# Altium schematic sheet main.SchDoc
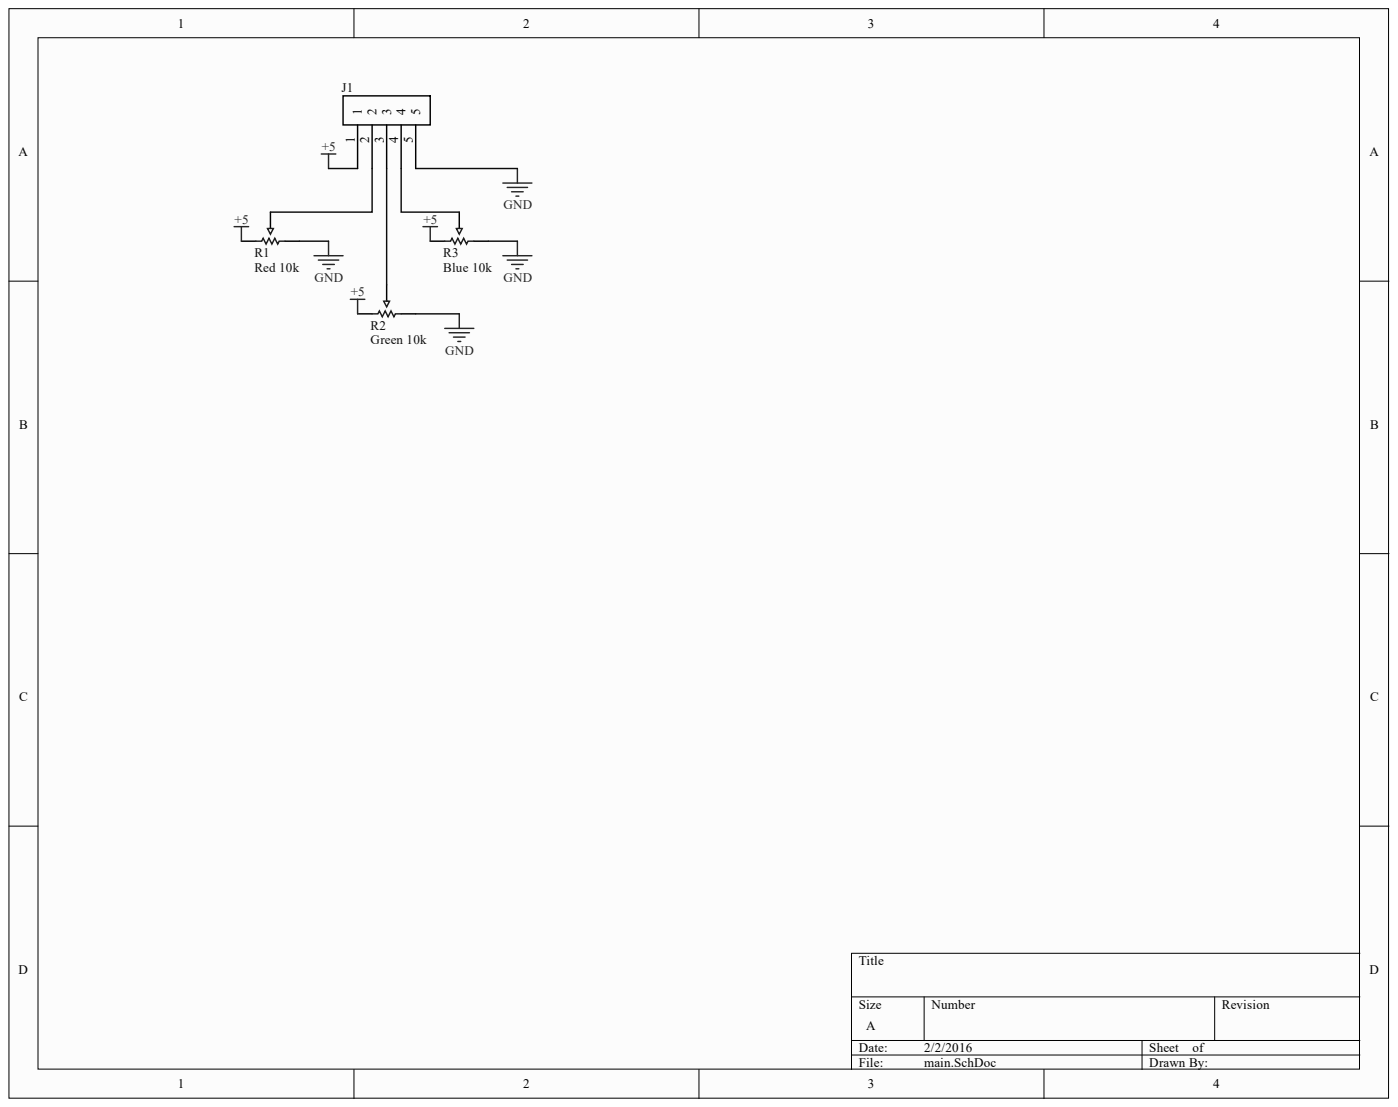
[diagram p0: main.SchDoc]

## Parts
J1  (no value)  [PCB-e3d7edbgjzh0cudui8h6-1]
R1  Red 10k  [PCB-3yfbg5qgmic6c05pdvzs-1]
R2  Green 10k  [PCB-3yfbg5qgmic6c05pdvzs-1]
R3  Blue 10k  [PCB-3yfbg5qgmic6c05pdvzs-1]

## Nets
+5: J1.1 R1.1 R2.1 R3.1
GND: J1.5 R1.2 R2.2 R3.2
NetJ1_2: J1.2 R1.3
NetJ1_3: J1.3 R2.3
NetJ1_4: J1.4 R3.3

## Library data of the parts
J1 = CMP-549d9d94c1688b5a-2: Conn Unshrouded Header HDR 15 POS 2.54mm Solder ST Thru-Hole; Manufacturer Part Number: 1-87224-5; ELV Compliant: Compliant; Lead-Free Status: Lead Free; Mounting Angle: 180.0 °; Number of Positions: 15; Pin Pitch: 0.00254 m; Component Kind: Standard; Contact Material: Phosphor Bronze; Contact Plating: Gold Flash, Gold Flash over Nickel, Gold; Flammability Rating: UL 94 V-0; Housing Color: Black; Housing Material: Thermoplastic; Library Name: CommunityVault; Lifecycle Status: Active; Mounting Style: PCB; Number of Rows: 1; OctopartID: 549d9d94c1688b5a; Octopart URL: http://octopart.com/1-87224-5-te+connectivity+%2F+amp-241811; Orientation: Vertical; Packaging: Bulk; RoHS: Compliant; Size-Height: 0.00229 m; Size-Length: 0.03769 m
R1-R3 = CMP-ee1c270d5a4931db-1: Res Carbon Film POT 50K Ohm 20% 1/5W 1(Elec)/1(Mech)Turn 6mm (29.5 X 17 X 21mm) Pin Panel Mount/Through Hole; Manufacturer Part Number: PDB181-K220K-503B; Power Rating: 0.2 W; Voltage Rating (DC): 200.0 V; Component Kind: Standard; Library Name: CommunityVault; OctopartID: ee1c270d5a4931db; Octopart URL: http://octopart.com/pdb181-k220k-503b-bourns-1806643; Resistance Tolerance: ±20%; RoHS: Compliant
